# Altium schematic sheet 2. RELAY.SchDoc("2. RELAY")
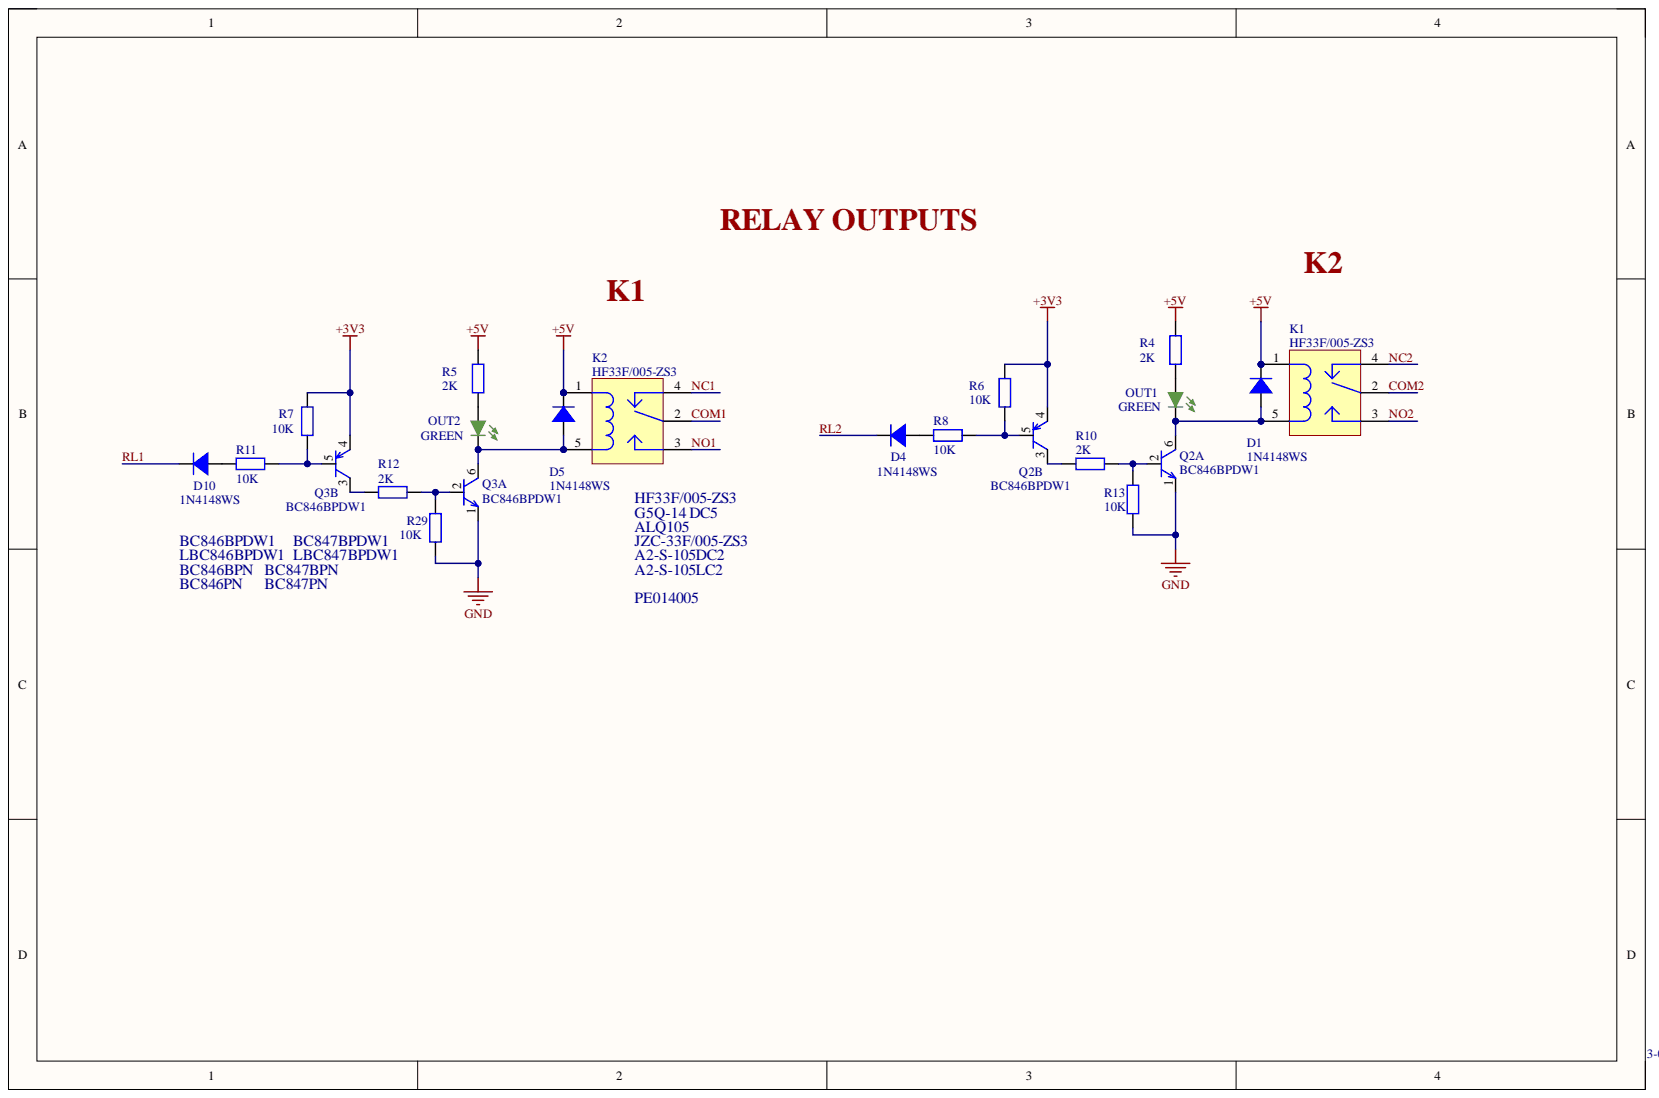
[diagram p0: 2. RELAY.SchDoc]

## Parts
D1  1N4148WS  [SOD323FL]
D4  1N4148WS  [SOD323FL]
D5  1N4148WS  [SOD323FL]
D10  1N4148WS  [SOD323FL]
K1  HF33F/005-ZS3  [PANASONIC_ALQ105]
K2  HF33F/005-ZS3  [PANASONIC_ALQ105]
OUT1  GREEN  [LED0603_GREEN]
OUT2  GREEN  [LED0603_GREEN]
Q2  BC846BPDW1  [SOT363]  (parts A,B)
Q3  BC846BPDW1  [SOT363]  (parts A,B)
R4  2K  [RESC-0603 (1608) - HD]
R5  2K  [RESC-0603 (1608) - HD]
R6  10K  [RESC-0603 (1608) - HD]
R7  10K  [RESC-0603 (1608) - HD]
R8  10K  [RESC-0603 (1608) - HD]
R10  2K  [RESC-0603 (1608) - HD]
R11  10K  [RESC-0603 (1608) - HD]
R12  2K  [RESC-0603 (1608) - HD]
R13  10K  [RESC-0603 (1608) - HD]
R29  10K  [RESC-0603 (1608) - HD]

## Nets
+3V3: Q2.4 Q3.4 R6.1 R7.1
+5V: D1.1 D5.1 K1.1 K2.1 R4.2 R5.2
GND: Q2.1 Q3.1 R13.2 R29.2
COM1: K2.2
COM2: K1.2
NC1: K2.4
NC2: K1.4
NO1: K2.3
NO2: K1.3
RL1: D10.1
RL2: D4.1
NetD1_2: D1.2 K1.5 OUT1.K Q2.6
NetD4_2: D4.2 R8.1
NetD5_2: D5.2 K2.5 OUT2.K Q3.6
NetD10_2: D10.2 R11.1
NetOUT1_A: OUT1.A R4.1
NetOUT2_A: OUT2.A R5.1
NetQ2_2: Q2.2 R10.1 R13.1
NetQ2_3: Q2.3 R10.2
NetQ2_5: Q2.5 R6.2 R8.2
NetQ3_2: Q3.2 R12.1 R29.1
NetQ3_3: Q3.3 R12.2
NetQ3_5: Q3.5 R7.2 R11.2

## Library data of the parts
D1 D4 D5 D10 = DIODE_1N4148WS: DIODE GEN PURP 75V 150MA SOD323F; Manufacturer: ON Semiconductor / Fairchild; Element Configuration: Single; Forward Voltage: 1V; Max Forward Surge Current (Ifsm): 2A; Max Reverse Current: 5uA; Power Dissipation: 200mW; Recovery Time: 4ns; Average Rectified Current: 150mA; Category: Rectifier Diodes; Component Kind: Standard; ComponentLink1Description: https://datasheet.ciiva.com/25968/1n914bws-25968945.pdf?src-supplier=Avnet+Europe; ComponentLink1URL: https://datasheet.ciiva.com/25968/1n914bws-25968945.pdf?src-supplier=Avnet+Europe; ComponentLink2Description: https://datasheet.ciiva.com/30479/0900766b810e1cd3-30479206.pdf?src-supplier=RSComponents; ComponentLink2URL: https://datasheet.ciiva.com/30479/0900766b810e1cd3-30479206.pdf?src-supplier=RSComponents; ComponentLink3Description: https://datasheet.ciiva.com/8300/1n914bws-8300360.pdf?src-supplier=Avnet; ComponentLink3URL: https://datasheet.ciiva.com/8300/1n914bws-8300360.pdf?src-supplier=Avnet; ComponentLink4Description: https://datasheet.ciiva.com/15208/getdatasheetpartid-127819-15208358.pdf?src-supplier=Verical; ComponentLink4URL: https://datasheet.ciiva.com/15208/getdatasheetpartid-127819-15208358.pdf?src-supplier=Verical; ComponentLink5Description: https://datasheet.ciiva.com/910/1n4148ws-910381.pdf?src-supplier=Digi-Key; ComponentLink5URL: https://datasheet.ciiva.com/910/1n4148ws-910381.pdf?src-supplier=Digi-Key; ComponentLink6Description: https://datasheet.ciiva.com/910/1n4148ws-910382.pdf?src-supplier=Digi-Key; ComponentLink6URL: https://datasheet.ciiva.com/910/1n4148ws-910382.pdf?src-supplier=Digi-Key; ComponentLink7Description: https://datasheet.ciiva.com/910/1n4148ws-910380.pdf?src-supplier=Digi-Key; ComponentLink7URL: https://datasheet.ciiva.com/910/1n4148ws-910380.pdf?src-supplier=Digi-Key; Contact Plating: Tin,Matte; Forward Current: 150mA; Height: 1mm; Lead Free: Lead Free; Length: 1.8mm; Manufacturer Part Number: 1N4148WS; Max Operating Temperature: 150°C; Max Repetitive Reverse Voltage (Vrrm): 75V; Max Reverse Voltage (DC): 75V; Min Operating Temperature: -55°C; Mount: Surface Mount; Number of Pins: 2; Package Quantity: 1; Packaging: Tape and Reel; Pricing 1: 1=0.21000, 10=0.19200, 100=0.10460, 500=0.06436, 1000=0.04388 (USD); Radiation Hardening: No; REACH SVHC: No SVHC; Reverse Recovery Time: 4ns; Reverse Voltage: 75V; RoHS: Yes; RoHS Compliant: Yes; Series: -; Stock 1: 680869; Supplier 1: Digi-Key; Supplier Part Number 1: 1N4148WSFSCT-ND; Weight: 0.0276g; Width: 1.35mm; Library Name: Bokra.SCHLIB
K1 K2 = RELAY_HF33F/005-ZS3: RELAY GEN PURPOSE SPDT 10A 5V; Component Kind: Standard; Library Name: Bokra.SCHLIB
OUT1 OUT2 = LED0603_GREEN: LED GREEN CLEAR SMD; Manufacturer: XINGLIGHT; Manufacturer Part Number: XL-1608SYGC-06; Dominant Wavelength: 571nm; Forward Current: 30mA; Forward Voltage: 2V; Lens Color: Colorless; Lens Style: Rectangular,Colorless; Luminous Intensity: 35mcd; Max Power Dissipation: 75mW; Number of Elements: 1; Reverse Voltage: 5V; Test Current: 20mA; Viewing Angle: 130°; Wavelength: 574nm; Case Code (Imperial): 0603; Case Code (Metric): 1608; Case/Package: 0603; Category: LEDs; Color: Green; Component Kind: Standard; ComponentLink1Description: https://datasheet.ciiva.com/2109/ltst-c191kgkt-2109973.pdf?src-supplier=Digi-Key; ComponentLink1URL: https://datasheet.ciiva.com/2109/ltst-c191kgkt-2109973.pdf?src-supplier=Digi-Key; Depth: 0.8mm; Height: 0.55mm; Illumination Color: Green; Lead Free: Lead Free; Length: 1.6mm; Lens Transparency: Clear; Max Operating Temperature: 85°C; Min Operating Temperature: -55°C; Mount: Surface Mount; Number of LEDs: 1; Number of Pins: 2; Package Quantity: 1; Packaging: Tape and Reel; Power Dissipation: 75mW; Power Rating: 75mW; Pricing 1: 1=0.26000, 10=0.14100, 100=0.06560, 500=0.06060, 1000=0.04747, 2000=0.04646 (USD); Radiation Hardening: No; RoHS: Yes; RoHS Compliant: Yes; Stock 1: 910352; Supplier 1: LCSC; Supplier Part Number 1: C965805; Width: 0.8mm; Library Name: Bokra.SCHLIB
Q2 Q3 = BC846BPDW1_2parts: Dual PNP/NPN transistors SOT363; Component Kind: Standard; PartNumber1: BC846BPDW1; PartNumber2: BC846BPN; Value: BC846BPDW1; Library Name: Bokra.SCHLIB
R4 R5 R10 R12 = RC0603FR-072KL: RES SMD 2 KOHM 1% 1/10W 0603; Solder: SMT; Component Kind: Standard; LCSC #: C105576; MPN: RC0603FR-072KL; Value: 2K; Library Name: Bokra.SCHLIB
R6-R8 R11 R13 R29 = RC0603FR-0710KL: RES 10K OHM 1% 1/10W 0603; MFR: YAGEO; Solder: SMT; Component Kind: Standard; LCSC #: C98220; MPN: RC0603FR-0710KL; Value: 10K; Library Name: Bokra.SCHLIB
